# Altium schematic sheet Display.SchDoc
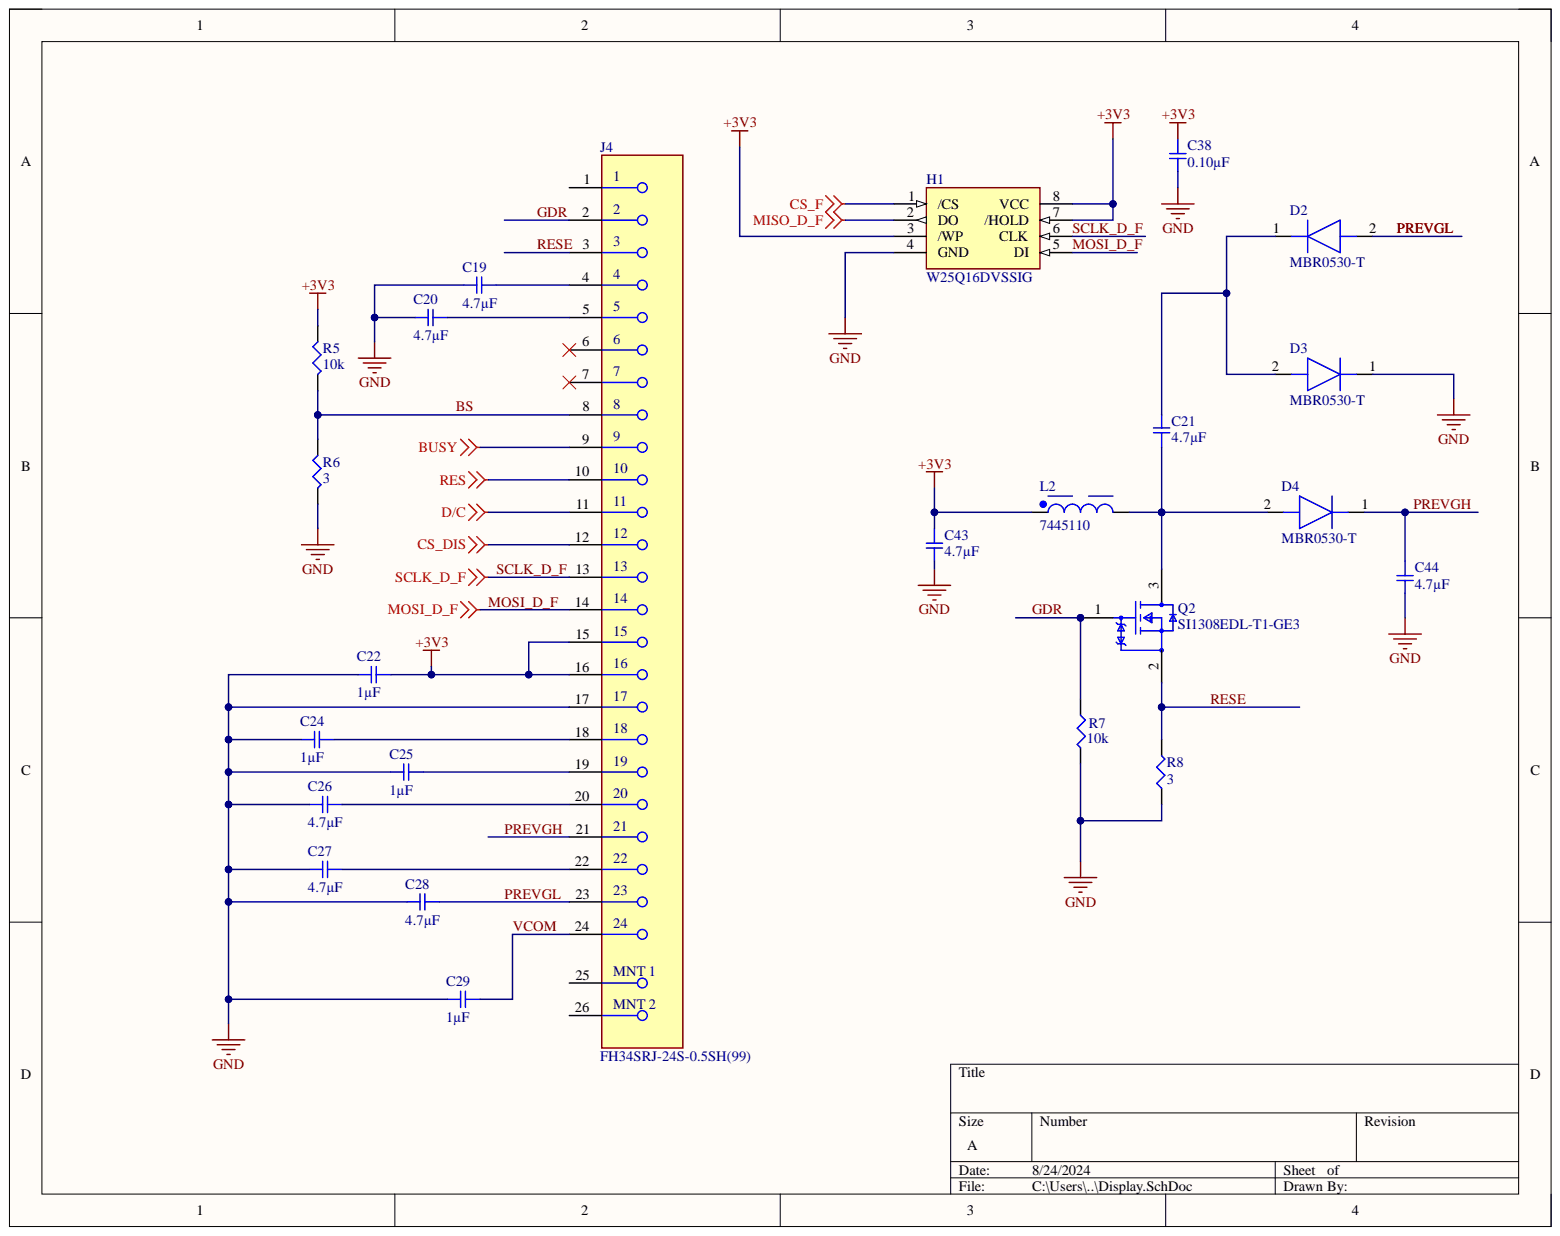
[diagram p0: Display.SchDoc]

## Parts
C19  4.7µF  [SMD-0402C]
C20  4.7µF  [SMD-0402C]
C21  4.7µF  [SMD-0402C]
C22  1µF  [SMD-0402C]
C24  1µF  [SMD-0402C]
C25  1µF  [SMD-0402C]
C26  4.7µF  [SMD-0402C]
C27  4.7µF  [SMD-0402C]
C28  4.7µF  [SMD-0402C]
C29  1µF  [SMD-0402C]
C38  0.10µF  [SMD-0402C]
C43  4.7µF  [SMD-0402C]
C44  4.7µF  [SMD-0402C]
D2  MBR0530-T  [SOD3716X135N]
D3  MBR0530-T  [SOD3716X135N]
D4  MBR0530-T  [SOD3716X135N]
H1  W25Q16DVSSIG  [SOIC127P790X216-8N]
J4  FH34SRJ-24S-0.5SH(99)  [FP-FH34SRJ-24S-0_5SH_99-MFG]
L2  7445110  [WE-PD3_Type S]
Q2  SI1308EDL-T1-GE3  [FP-5549-MFG]
R5  10k  [SMD-0402-RES]
R6  3  [SMD-0402-RES]
R7  10k  [SMD-0402-RES]
R8  3  [SMD-0402-RES]

## Nets
+3V3: C22.1 C38.1 C43.1 H1.3(/WP) H1.7(/HOLD) H1.8(VCC) J4.15 J4.16 L2.1 R5.1
BUSY: J4.9
CS_DIS: J4.12
CS_F: H1.1(/CS)
D/C: J4.11
GND: C19.2 C20.2 C22.2 C24.2 C25.2 C26.2 C27.2 C28.2 C29.2 C38.2 C43.2 C44.2 D3.1 H1.4(GND) J4.17 R6.2 R7.2 R8.1
MISO_D_F: H1.2(DO)
RES: J4.10
BS: J4.8 R5.2 R6.1
GDR: J4.2 Q2.1 R7.1
MOSI_D_F: H1.5(DI) J4.14
PREVGH: C44.1 D4.1 J4.21
PREVGL: C28.1 D2.2 J4.23
RESE: J4.3 Q2.2 R8.2
SCLK_D_F: H1.6(CLK) J4.13
VCOM: C29.1 J4.24
NetC19_1: C19.1 J4.4
NetC20_1: C20.1 J4.5
NetC21_1: C21.1 D4.2 L2.2 Q2.3
NetC21_2: C21.2 D2.1 D3.2
NetC24_1: C24.1 J4.18
NetC25_1: C25.1 J4.19
NetC26_1: C26.1 J4.20
NetC27_1: C27.1 J4.22

Not connected: J4 1 6 7 25 26

## Library data of the parts
C19-C21 C26-C28 = ZRB15XR61A475ME01D: CAP CER 4.7UF 10V X5R 0402; Manufacturer: Murata Electronics North America; @ qty: 0; Minimum Quantity: 1; Tolerance: ±20%; Unit Price (USD): 0.36; Applications: Acoustic Noise Reduction; Component Kind: Standard; Datasheets: http://search.murata.co.jp/Ceramy/image/img/A01X/G101/ENG/ZRB15XR61A475ME01-01.pdf; Digi-Key Part Number: 490-10984-1-ND; Factory Stock: 0; Features: Derating Recommended, Interposer Termination; Height - Seated (Max): -; Image: //media.digikey.com/Renders/Murata%20Renders/ZRB-SERIES-0402.jpg; Lead Spacing: -; Lead Style: -; Library Name: capacitor_murata_0402.IntLib; Mounting Type: Surface Mount, MLCC; Operating Temperature: -55°C ~ 85°C; Package / Case: 0402 (1005 Metric); Packaging: Cut Tape (CT); Part Number: ZRB15XR61A475ME01D; Part Status: Active; Quantity Available: 53897; Ratings: -; Series: ZRB; Size / Dimension: 0.039" L x 0.020" W (1.00mm x 0.50mm); Temperature Coefficient: X5R; Thickness (Max): 0.031" (0.80mm); Value: 4.7µF; Voltage: 10V
C22 C24 C25 C29 = GRM155R61E105MA12D: CAP CER 1UF 25V X5R 0402; Manufacturer: Murata Electronics North America; @ qty: 0; Minimum Quantity: 1; Tolerance: ±20%; Unit Price (USD): 0.1; Applications: General Purpose; Component Kind: Standard; Datasheets: http://www.murata.com/~/media/webrenewal/support/library/catalog/products/capacitor/mlcc/c02e.pdf; Digi-Key Part Number: 490-10018-1-ND; Factory Stock: 0; Features: Derating Recommended; Height - Seated (Max): -; Image: //media.digikey.com/Renders/Murata%20Renders/ZRB-SERIES-0402.jpg; Lead Spacing: -; Lead Style: -; Library Name: capacitor_murata_0402.IntLib; Mounting Type: Surface Mount, MLCC; Operating Temperature: -55°C ~ 85°C; Package / Case: 0402 (1005 Metric); Packaging: Cut Tape (CT); Part Number: GRM155R61E105MA12D; Part Status: Active; Quantity Available: 32609; Ratings: -; Series: GRM; Size / Dimension: 0.039" L x 0.020" W (1.00mm x 0.50mm); Temperature Coefficient: X5R; Thickness (Max): 0.022" (0.55mm); Value: 1µF; Voltage: 25V
C38 = GCM155R71C104KA55D: CAP CER 0.1UF 16V X7R 0402; Manufacturer: Murata Electronics North America; @ qty: 0; Minimum Quantity: 1; Tolerance: ±10%; Unit Price (USD): 0.1; Applications: Automotive; Component Kind: Standard; Datasheets: http://www.murata.com/~/media/webrenewal/support/library/catalog/products/capacitor/mlcc/c03e.ashx; Digi-Key Part Number: 490-4759-1-ND; Factory Stock: 0; Features: -; Height - Seated (Max): -; Image: //media.digikey.com/Renders/Murata%20Renders/ZRB-SERIES-0402.jpg; Lead Spacing: -; Lead Style: -; Library Name: capacitor_murata_0402.IntLib; Mounting Type: Surface Mount, MLCC; Operating Temperature: -55°C ~ 125°C; Package / Case: 0402 (1005 Metric); Packaging: Cut Tape (CT); Part Number: GCM155R71C104KA55D; Part Status: Active; Quantity Available: 734194; Ratings: AEC-Q200; Series: GCM; Size / Dimension: 0.039" L x 0.020" W (1.00mm x 0.50mm); Temperature Coefficient: X7R; Thickness (Max): 0.022" (0.55mm); Value: 0.10µF; Voltage: 16V
C43 C44 = ZRB15XR61A475KE01D: CAP CER 4.7UF 10V X5R 0402; Manufacturer: Murata Electronics North America; @ qty: 0; Minimum Quantity: 1; Tolerance: ±10%; Unit Price (USD): 0.28; Applications: Acoustic Noise Reduction; Component Kind: Standard; Datasheets: http://search.murata.co.jp/Ceramy/image/img/A01X/G101/ENG/ZRB15XR61A475KE01-01.pdf; Digi-Key Part Number: 490-13252-1-ND; Factory Stock: 0; Features: Derating Recommended, Interposer Termination; Height - Seated (Max): -; Image: //media.digikey.com/Renders/Murata%20Renders/ZRB-SERIES-0402.jpg; Lead Spacing: -; Lead Style: -; Library Name: capacitor_murata_0402.IntLib; Mounting Type: Surface Mount, MLCC; Operating Temperature: -55°C ~ 85°C; Package / Case: 0402 (1005 Metric); Packaging: Cut Tape (CT); Part Number: ZRB15XR61A475KE01D; Part Status: Active; Quantity Available: 23076; Ratings: -; Series: ZRB; Size / Dimension: 0.039" L x 0.020" W (1.00mm x 0.50mm); Temperature Coefficient: X5R; Thickness (Max): 0.031" (0.80mm); Value: 4.7µF; Voltage: 10V
D2-D4 = MBR0530-T: Diode; Manufacturer_Name: MCC; Component Kind: Standard; Datasheet Link: https://componentsearchengine.com/Datasheets/1/MBR0530-T.pdf; Height: 1.35mm; Manufacturer_Part_Number: MBR0530-T; Mouser Part Number: 833-MBR0530-T; Mouser Price/Stock: https://www.mouser.co.uk/ProductDetail/Micro-Commercial-Components-MCC/MBR0530-T?qs=9VyI4qLX4NTSXkb9ynzJnA%3D%3D
H1 = W25Q16DVSSIG: Hardware; Manufacturer_Name: Winbond; Component Kind: Standard; Datasheet Link: https://www.winbond.com/resource-files/da00-w25q16dv_f2.pdf; Height: 2.16mm; Manufacturer_Part_Number: W25Q16DVSSIG; Mouser Part Number: N/A; Mouser Price/Stock: https://www.mouser.co.uk/ProductDetail/Winbond/W25Q16DVSSIG?qs=rkhjVJ6%2F3EKYKn%2FdfCfLNQ%3D%3D
J4 = CMP-11609-000022-1: CONN FPC/FFC 24POS .5MM; Actuator Material: Nylon; Current Rating: 500mA; Number of Positions: 24; Pitch: 0.02inch; Voltage Rating: 50V; Component Kind: Standard; Contact Material: Bronze; Contact Plating: Gold; Flammability Rating: UL94 V-0; Housing Material: Polymer; Library Name: Altium Content Vault; Max Operating Temperature: 85°C; Min Operating Temperature: -55°C; Mount: Surface Mount; Number of Pins: 24; Packaging: Tape and Reel; RoHS Compliant: Yes; Termination: Solder
L2 = CMP-0227-00829-2: NexarPartId: 8917249; Core Material: Ferrite; Current Rating: 1A; DC Resistance (DCR): 56mΩ; Inductance: 10µH; Inductance Tolerance: 20%; Saturation Current: 450mA; Self Resonant Frequency: 30MHz; Shielding: Shielded; Test Frequency: 100kHz; Ambient Temperature Range High: 85°C; Ambient Temperature Range Low: -40°C; Case/Package: Nonstandard; Component Kind: Standard; Composition: Wirewound; Depth: 4.45mm; Height: 2.92mm; Height - Seated (Max): 2.9972mm; Lead Free: Lead Free; Length: 6.6mm; Library Name: Altium Content Vault; Max DC Current: 1A; Max Operating Temperature: 125°C; Min Operating Temperature: -40°C; Mount: Surface Mount; Number of Pins: 2; Packaging: Cut Tape (CT); REACH SVHC: Unknown; Resistance: 56mΩ; RMS Current (Irms): 1A; RoHS: Compliant; Tolerance: 20%; Width: 4.45mm
Q2 = CMP-02550-000099-2: MOSFET N-CH 30V 1.4A SC-70-3; Continuous Drain Current (ID): 1.5A; Drain to Source Resistance: 132mR; Element Configuration: Single; Fall Time: 8ns; Gate to Source Voltage (Vgs): 12V; Input Capacitance: 105pF; Max Power Dissipation: 500mW; Number of Channels: 1; Polarity: N-CHANNEL; Power Dissipation: 400mW; Rise Time: 9ns; Threshold Voltage: 600mV; Turn-On Delay Time: 2ns; Case/Package: SOT-323; Component Kind: Standard; Drain to Source Breakdown Voltage: 30V; Drain to Source Voltage (Vdss): 30V; Drain-source On Resistance-Max: 132mR; Height: 1mm; Lead Free: Lead Free; Length: 2.2mm; Library Name: Altium Content Vault; Max Operating Temperature: 150°C; Min Operating Temperature: -55°C; Mount: Surface Mount; Number of Elements: 1; Number of Pins: 3; On-State Resistance: 132mR; Package Quantity: 3000; Packaging: Tape and Reel; Radiation Hardening: No; Rds On Max: 132mR; REACH SVHC: No SVHC; RoHS Compliant: Yes; Series: TrenchFET®; Turn-Off Delay Time: 8ns; Weight: 0.004395oz; Width: 1.35mm
R5 R7 = ERJ-2GEJ103X: RES SMD 10K OHM 5% 1/10W 0402; Manufacturer: Panasonic Electronic Components; @ qty: 1500; Factory Stock: 0; Minimum Quantity: 1; Power (Watts): 0.1W, 1/10W; Temperature Coefficient: ±200ppm/°C; Unit Price (USD): 0.00350; Component Kind: Standard; Composition: Thick Film; Datasheets: http://industrial.panasonic.com/www-cgi/jvcr13pz.cgi?E+PZ+3+AOA0001+ERJ2GEJ103X+7+WW; Digi-Key Part Number: P10KJCT-ND; Failure Rate: -; Features: Automotive AEC-Q200; Height - Seated (Max): 0.016" (0.40mm); Image: //media.digikey.com/Photos/Panasonic%20Photos/ERJ-PA2-Series.jpg; Library Name: Panasonic_ERJ_0402.IntLib; Number of Terminations: 2; Operating Temperature: -55°C ~ 155°C; Package / Case: 0402 (1005 Metric); Packaging: Cut Tape (CT); Part Number: ERJ-2GEJ103X; Part Status: Active; Quantity Available: 2560215; Series: ERJ; Size / Dimension: 0.039" L x 0.020" W (1.00mm x 0.50mm); Supplier Device Package: 0402; Tolerance: ±5%; Value: 10k
R6 R8 = ERJ-2GEJ3R0X: RES SMD 3 OHM 5% 1/10W 0402; Manufacturer: Panasonic Electronic Components; @ qty: 1500; Factory Stock: 0; Minimum Quantity: 1; Power (Watts): 0.1W, 1/10W; Temperature Coefficient: -100/ +600ppm/°C; Unit Price (USD): 0.00350; Component Kind: Standard; Composition: Thick Film; Datasheets: http://industrial.panasonic.com/www-cgi/jvcr13pz.cgi?E+PZ+3+AOA0001+ERJ2GEJ3R0X+7+WW; Digi-Key Part Number: P3.0JCT-ND; Failure Rate: -; Features: Automotive AEC-Q200; Height - Seated (Max): 0.016" (0.40mm); Image: //media.digikey.com/Photos/Panasonic%20Photos/ERJ-PA2-Series.jpg; Library Name: Panasonic_ERJ_0402.IntLib; Number of Terminations: 2; Operating Temperature: -55°C ~ 155°C; Package / Case: 0402 (1005 Metric); Packaging: Cut Tape (CT); Part Number: ERJ-2GEJ3R0X; Part Status: Active; Quantity Available: 212144; Series: ERJ; Size / Dimension: 0.039" L x 0.020" W (1.00mm x 0.50mm); Supplier Device Package: 0402; Tolerance: ±5%; Value: 3
